# Altium schematic sheet LTC2949.SchDoc
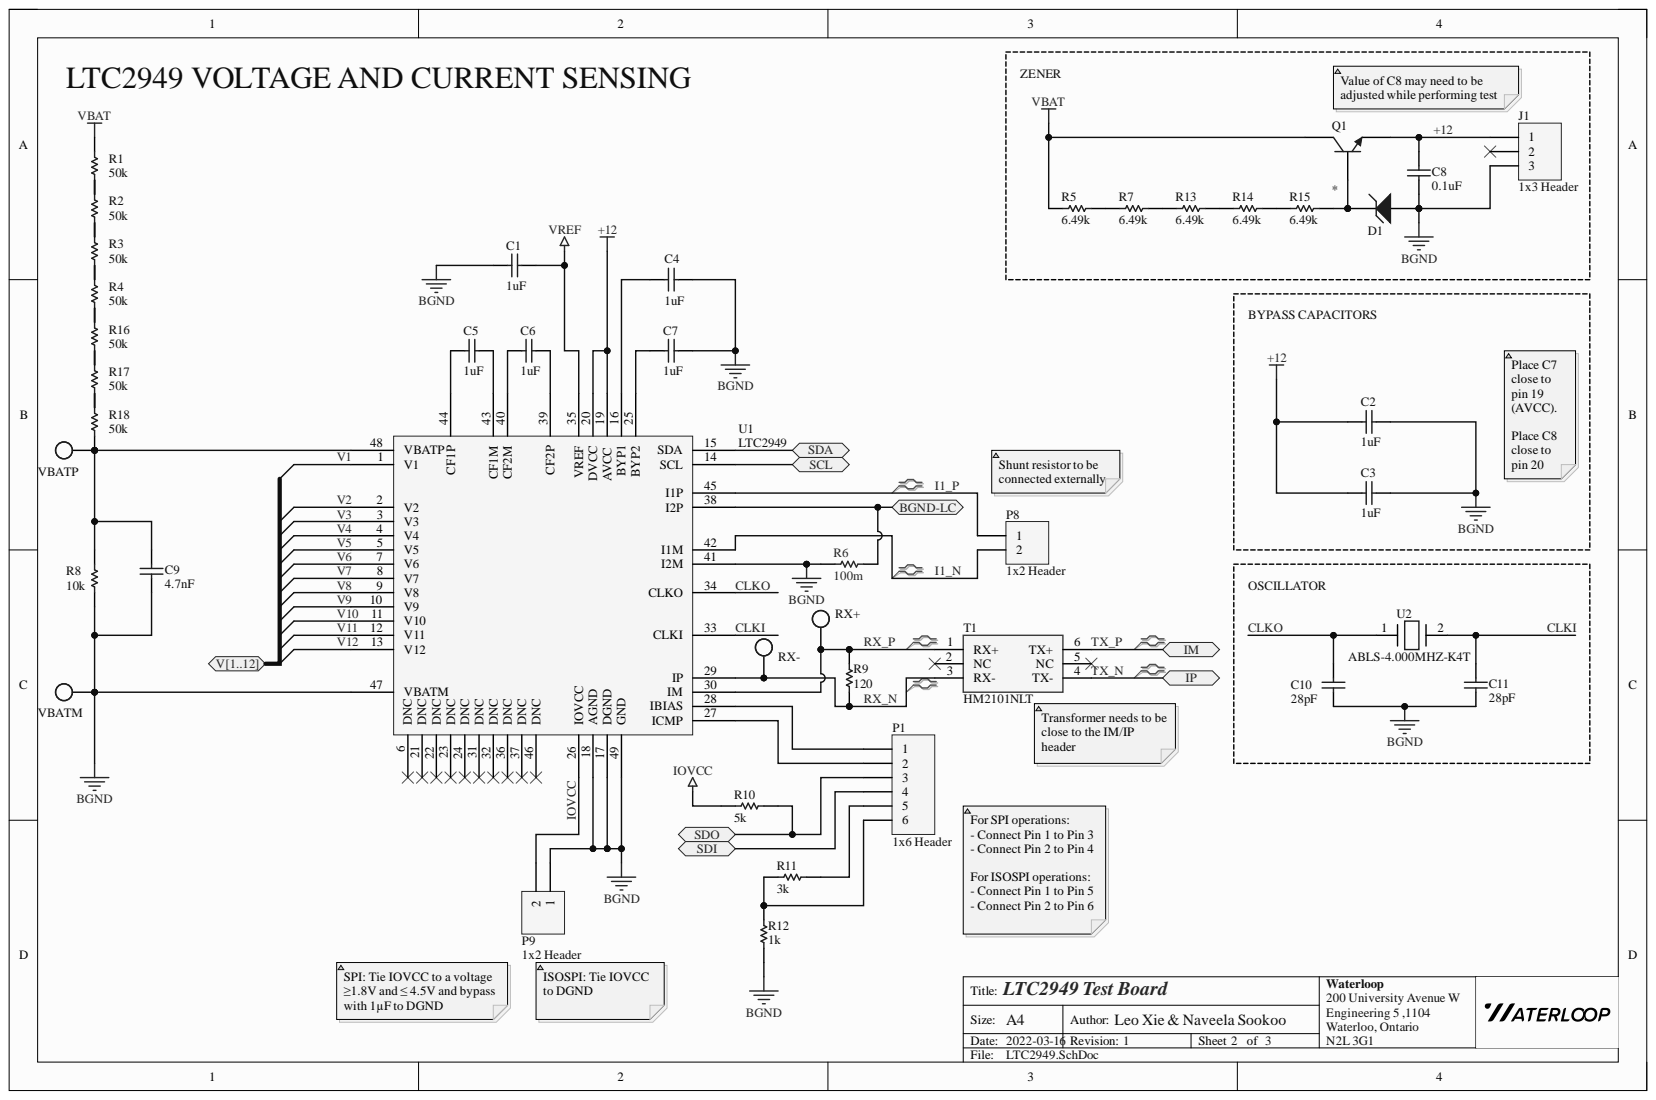
[diagram p0: LTC2949.SchDoc]

## Parts
C1  value 1uF  [0805 - Capacitor]  (hidden comment: Capacitor - Nonpolarized)
C2  value 1uF  [0805 - Capacitor]  (hidden comment: Nonpolarized Capacitor)
C3  value 1uF  [0805 - Capacitor]  (hidden comment: Nonpolarized Capacitor)
C4  value 1uF  [0805 - Capacitor]  (hidden comment: Nonpolarized Capacitor)
C5  value 1uF  [0805 - Capacitor]  (hidden comment: Nonpolarized Capacitor)
C6  value 1uF  [0805 - Capacitor]  (hidden comment: Nonpolarized Capacitor)
C7  value 1uF  [0805 - Capacitor]  (hidden comment: Nonpolarized Capacitor)
C8  value 0.1uF  [0805 - Capacitor]  (hidden comment: Nonpolarized Capacitor)
C9  value 4.7nF  [0805 - Capacitor]  (hidden comment: Nonpolarized Capacitor)
C10  value 28pF  [0805 - Capacitor]  (hidden comment: Nonpolarized Capacitor)
C11  value 28pF  [0805 - Capacitor]  (hidden comment: Nonpolarized Capacitor)
D1  Zener  [BZD27C - ZENER DIODES]  (value not drawn)
J1  1x3 Header  [HDR1X3]
P1  1x6 Header  [HDR1X6]
P8  1x2 Header  [HDR1X2]
P9  1x2 Header  [HDR1X2]
Q1  NPN  [BJT NPN 800 V 4 A - 90 W Through Hole TO-220]  (value not drawn)
R1  value 50k  [1206 - Resistor]  (hidden comment: Resistor)
R2  value 50k  [1206 - Resistor]  (hidden comment: Resistor)
R3  value 50k  [1206 - Resistor]  (hidden comment: Resistor)
R4  value 50k  [1206 - Resistor]  (hidden comment: Resistor)
R5  value 6.49k  [0603 - Resistor]  (hidden comment: Resistor)
R6  value 100m  [0805 - Resistor]  (hidden comment: Resistor)
R7  value 6.49k  [0603 - Resistor]  (hidden comment: Resistor)
R8  value 10k  [0805 - Resistor]  (hidden comment: Resistor)
R9  value 120  [0603 - Resistor]  (hidden comment: Resistor)
R10  value 5k  [0603 - Resistor]  (hidden comment: Resistor)
R11  value 3k  [0603 - Resistor]  (hidden comment: Resistor)
R12  value 1k  [0603 - Resistor]  (hidden comment: Resistor)
R13  value 6.49k  [0603 - Resistor]  (hidden comment: Resistor)
R14  value 6.49k  [0603 - Resistor]  (hidden comment: Resistor)
R15  value 6.49k  [0603 - Resistor]  (hidden comment: Resistor)
R16  value 50k  [1206 - Resistor]  (hidden comment: Resistor)
R17  value 50k  [1206 - Resistor]  (hidden comment: Resistor)
R18  value 50k  [1206 - Resistor]  (hidden comment: Resistor)
RX+  Test Point  [Test Point - 5002]  (value not drawn)  (no BOM)
RX-  Test Point  [Test Point - 5002]  (value not drawn)  (no BOM)
T1  HM2101NLT  [HM2101NLT]
U1  LTC2949  [LTC2949]
U2  ABLS-4.000MHZ-K4T  [ABLS_4MHZ-K4T]
VBATM  Test Point  [Test Point - 5002]  (value not drawn)  (no BOM)
VBATP  Test Point  [Test Point - 5002]  (value not drawn)  (no BOM)

## Ports
BGND-LC, IM, IP, SCL, SDA, SDI, SDO, V1, V2, V3, V4, V5, V6, V7, V8, V9, V10, V11, V12, V[1..12]

## Nets
BGND: C1.1 C2.2 C3.2 C4.2 C7.2 C8.2 C9.1 C10.1 C11.1 D1.1 J1.3 P9.1 R6.1 R8.1 R12.2 U1.17(DGND) U1.18(AGND) U1.41(I2M) U1.47(VBATM) U1.49(GND) VBATM.1
VBAT: Q1.1 R1.2 R5.2
VREF: C1.2 U1.35(VREF)
+12: C2.1 C3.1 C8.1 J1.1 Q1.3 U1.19(AVCC) U1.20(DVCC)
BGND-LC: R6.2 U1.38(I2P) ; port BGND-LC
CLKI: C11.2 U1.33(CLKI) U2.2
CLKO: C10.2 U1.34(CLKO) U2.1
I1_N: P8.2 U1.42(I1M)
I1_P: P8.1 U1.45(I1P)
IOVCC: P9.2 R10.1 U1.26(IOVCC)
RX_N: R9.1 RX-.1 T1.3(RX-) U1.29(IP)
RX_P: R9.2 RX+.1 T1.1(RX+) U1.30(IM)
SCL: U1.14(SCL) ; port SCL
SDA: U1.15(SDA) ; port SDA
SDI: P1.4 ; port SDI
SDO: P1.3 R10.2 ; port SDO
TX_N: T1.4(TX-) ; port IP
TX_P: T1.6(TX+) ; port IM
V1: U1.1(V1) ; port V[1..12]  [also labelled ]
V2: U1.2(V2) ; port V[1..12]  [also labelled ]
V3: U1.3(V3) ; port V[1..12]  [also labelled ]
V4: U1.4(V4) ; port V[1..12]  [also labelled ]
V5: U1.5(V5) ; port V[1..12]  [also labelled ]
V6: U1.7(V6) ; port V[1..12]  [also labelled ]
V7: U1.8(V7) ; port V[1..12]  [also labelled ]
V8: U1.9(V8) ; port V[1..12]  [also labelled ]
V9: U1.10(V9) ; port V[1..12]  [also labelled ]
V10: U1.11(V10) ; port V[1..12]  [also labelled ]
V11: U1.12(V11) ; port V[1..12]  [also labelled ]
V12: U1.13(V12) ; port V[1..12]  [also labelled ]
NetC4_1: C4.1 U1.16(BYP1)
NetC5_1: C5.1 U1.44(CF1P)
NetC5_2: C5.2 U1.43(CF1M)
NetC6_1: C6.1 U1.40(CF2M)
NetC6_2: C6.2 U1.39(CF2P)
NetC7_1: C7.1 U1.25(BYP2)
NetC9_2: C9.2 R8.2 R18.1 U1.48(VBATP) VBATP.1
NetD1_2: D1.2 Q1.2 R15.1
NetP1_1: P1.1 U1.28(IBIAS)
NetP1_2: P1.2 U1.27(ICMP)
NetP1_5: P1.5 R11.2
NetP1_6: P1.6 R11.1 R12.1
NetR1_1: R1.1 R2.2
NetR2_1: R2.1 R3.2
NetR3_1: R3.1 R4.2
NetR4_1: R4.1 R16.2
NetR5_1: R5.1 R7.2
NetR7_1: R7.1 R13.2
NetR13_1: R13.1 R14.2
NetR14_1: R14.1 R15.2
NetR16_1: R16.1 R17.2
NetR17_1: R17.1 R18.2

Not connected: J1 2; T1 2(NC) 5(NC); U1 6(DNC) 21(DNC) 22(DNC) 23(DNC) 24(DNC) 31(DNC) 32(DNC) 36(DNC) 37(DNC) 46(DNC)

## Library data of the parts
C1 = Capacitor - Nonpolarized: Nonpolarized Capacitor; Component Kind: Standard; Library Name: General.SchLib; Signal Integrity: Cap; Simulation: CAP
C2-C11 = Capacitor - Nonpolarized: Component Kind: Standard; Description: Nonpolarized Capacitor; Library Name: General.SchLib; Signal Integrity: Cap; Simulation: CAP
D1 = Zener: Zener Diode; Component Kind: Standard; Library Name: General.SchLib
J1 = 1x3 Header: 1x3 Pin Header; Component Kind: Standard; Library Name: GeneralConnectors.SchLib; Signal Integrity: Connector
P1 = 1x6 Header: 1x6 Pin Header; Component Kind: Standard; Library Name: GeneralConnectors.IntLib; Signal Integrity: Connector
P8 P9 = 1x2 Header: 1x2 Pin Header; Component Kind: Standard; Signal Integrity: Connector
  P8: Library Name: GeneralConnectors.IntLib
  P9: Library Name: GeneralConnectors.SchLib
Q1 = NPN: NPN Bipolar Transistor; Component Kind: Standard; Library Name: General.SchLib; Signal Integrity: NPN; Simulation: NPN
T1 = HM2101NLT: Pulse Transformer 1:1 Surface Mount 150µH, 450µH; Component Kind: Standard; Library Name: GeneralComponents.SchLib
U1 = LTC2949: Manufacturer: Analog Devices; Manufacturer Part Number: LTC2949ILXE#3ZZPBF; Component Kind: Standard; Library Name: BMS.SchLib; Supplier: Mouser; Supplier Part Number: 584-2949ILXE3ZZPBF
U2 = ABLS-4.000MHZ-K4T: Crystal Oscillator 4MHz 18pF; Component Kind: Standard; Library Name: BMS.SchLib
R1-R18 = Resistor: Component Kind: Standard; Description: Resistor; Library Name: General.SchLib; Signal Integrity: Res; Simulation: RESISTOR
RX+ RX- VBATM VBATP = Test Point: Description: Test Point; Library Name: General.SchLib
